# Altium schematic sheet AWS KEY.SchDoc
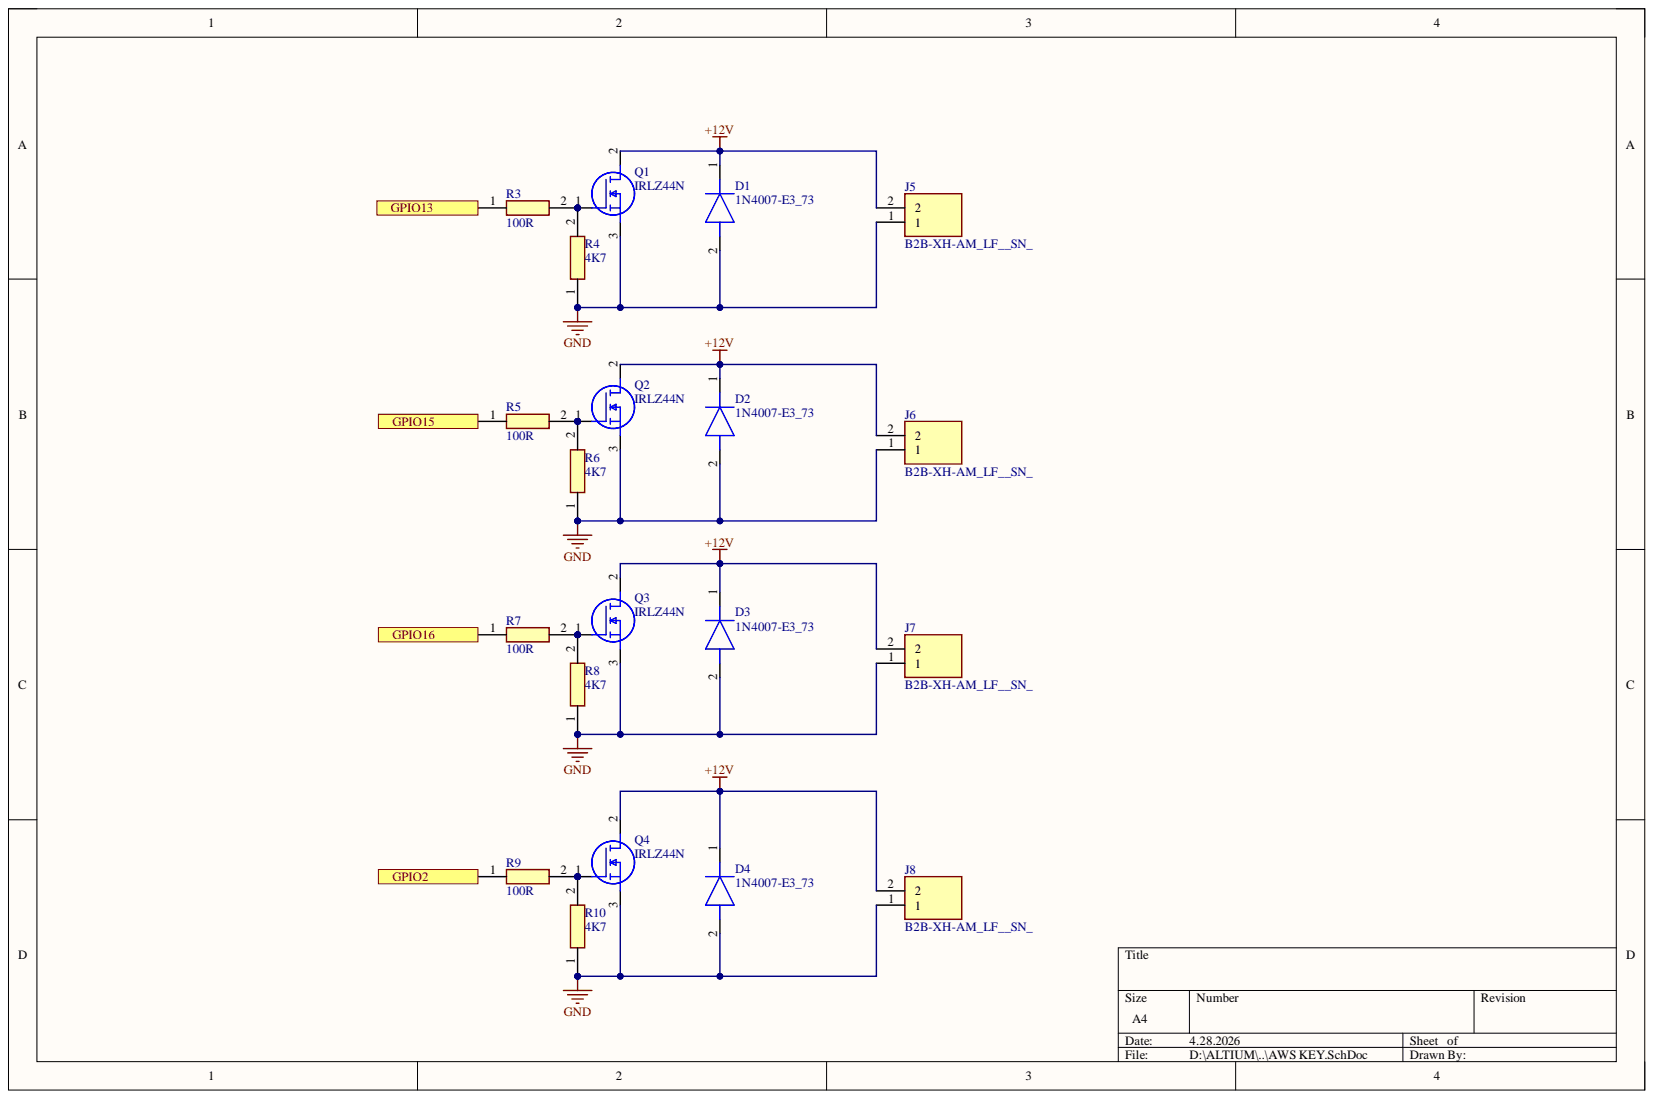
[diagram p0: AWS KEY.SchDoc]

## Parts
D1  1N4007-E3_73  [DIOAD1405W86L465D235]
D2  1N4007-E3_73  [DIOAD1405W86L465D235]
D3  1N4007-E3_73  [DIOAD1405W86L465D235]
D4  1N4007-E3_73  [DIOAD1405W86L465D235]
J5  B2B-XH-AM_LF__SN_  [B2BXHAMLFSN]
J6  B2B-XH-AM_LF__SN_  [B2BXHAMLFSN]
J7  B2B-XH-AM_LF__SN_  [B2BXHAMLFSN]
J8  B2B-XH-AM_LF__SN_  [B2BXHAMLFSN]
Q1  IRLZ44N  [TO254P469X1042X1967-3P]
Q2  IRLZ44N  [TO254P469X1042X1967-3P]
Q3  IRLZ44N  [TO254P469X1042X1967-3P]
Q4  IRLZ44N  [TO254P469X1042X1967-3P]
R3  100R  [RESC2012X60N]
R4  4K7  [RESC2012X60N]
R5  100R  [RESC2012X60N]
R6  4K7  [RESC2012X60N]
R7  100R  [RESC2012X60N]
R8  4K7  [RESC2012X60N]
R9  100R  [RESC2012X60N]
R10  4K7  [RESC2012X60N]

## Ports
GPIO2, GPIO13, GPIO15, GPIO16

## Nets
+12V: D1.1 D2.1 D3.1 D4.1 J5.2 J6.2 J7.2 J8.2 Q1.2 Q2.2 Q3.2 Q4.2
GND: D1.2 D2.2 D3.2 D4.2 J5.1 J6.1 J7.1 J8.1 Q1.3 Q2.3 Q3.3 Q4.3 R4.1 R6.1 R8.1 R10.1
GPIO2: R9.1 ; port GPIO2
GPIO13: R3.1 ; port GPIO13
GPIO15: R5.1 ; port GPIO15
GPIO16: R7.1 ; port GPIO16
NetQ1_1: Q1.1 R3.2 R4.2
NetQ2_1: Q2.1 R5.2 R6.2
NetQ3_1: Q3.1 R7.2 R8.2
NetQ4_1: Q4.1 R9.2 R10.2

## Library data of the parts
D1-D4 = 1N4007-E3_73: Diode; Manufacturer_Name: Vishay; Manufacturer_Part_Number: 1N4007-E3/73; Arrow Part Number: 1N4007-E3/73; Arrow Price/Stock: https://www.arrow.com/en/products/1n4007-e373/vishay?utm_currency=USD&region=europe; Component Kind: Standard; Datasheet Link: https://mouser.componentsearchengine.com/Datasheets/2/1N4007-E3_73.pdf; Library Name: SamacSys.SchLib; Mouser Part Number: 625-1N4007-E3/73; Mouser Price/Stock: https://www.mouser.co.uk/ProductDetail/Vishay-General-Semiconductor/1N4007-E3-73?qs=N4vtoAxH%2FSqT3Ng3e4K0Gw%3D%3D
J5-J8 = B2B-XH-AM_LF__SN_: Connector; Manufacturer_Name: JST (JAPAN SOLDERLESS TERMINALS); Manufacturer_Part_Number: B2B-XH-AM(LF)(SN); Arrow Part Number: B2B-XH-AM(LF)(SN); Arrow Price/Stock: https://www.arrow.com/en/products/b2b-xh-am-lf-sn/jst-manufacturing?utm_currency=USD&region=europe; Component Kind: Standard; Datasheet Link: https://www.jst-mfg.com/product/pdf/eng/eXH.pdf; Height: 7mm; Mouser Part Number: 306-B2B-XH-AMLFSNP; Mouser Price/Stock: https://www.mouser.co.uk/ProductDetail/JST-Commercial/B2B-XH-AMLFSN?qs=cdbOS8ANM9BJgHgwB7WxQw%3D%3D
Q1-Q4 = IRLZ44N: MOSFET (N-Channel); Manufacturer_Name: Infineon; Component Kind: Standard; Datasheet Link: https://www.mouser.com/catalog/specsheets/international rectifier_irlz44n.pdf; Height: 4.69mm; Manufacturer_Part_Number: IRLZ44N; Mouser Part Number: N/A; Mouser Price/Stock: https://www.mouser.co.uk/ProductDetail/Infineon-IR/IRLZ44N?qs=zO0nedAqnNIAphT7g5cSVQ%3D%3D
R3-R10 = RC0805FR-071ML: Resistor; Manufacturer_Name: Yageo Group; Arrow Part Number: RC0805FR-071ML; Arrow Price/Stock: https://www.arrow.com/en/products/rc0805fr-071ml/yageo.html?utm_currency=USD&region=europe; Component Kind: Standard; Datasheet Link: https://www.yageo.com/upload/media/product/productsearch/datasheet/rchip/PYu-RC_Group_51_RoHS_L_11.pdf; Height: 0.6mm; Library Name: SamacSys.SchLib; Manufacturer_Part_Number: RC0805FR-071ML; Mouser Part Number: 603-RC0805FR-071ML; Mouser Price/Stock: https://www.mouser.co.uk/ProductDetail/YAGEO/RC0805FR-071ML?qs=8Y8p%252BasKcI6wvSL4jxV1pQ%3D%3D
